# Altium schematic sheet con_brushless_v2.SchDoc
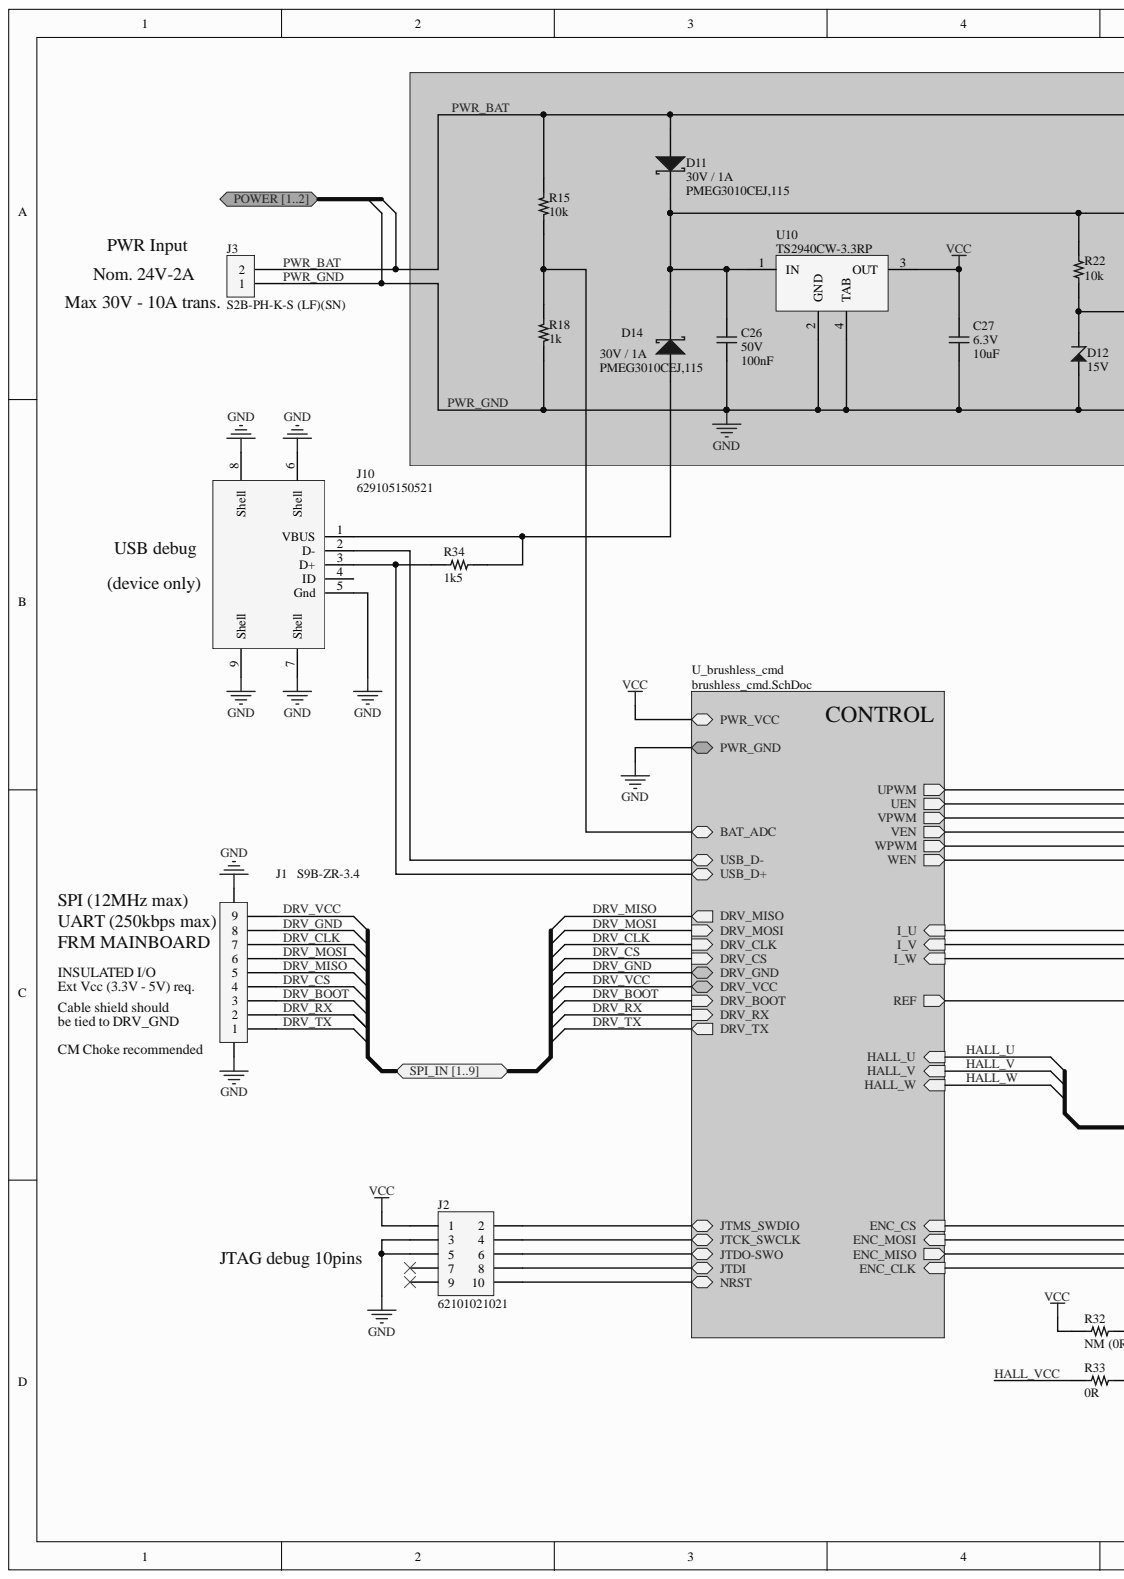
[diagram p0: con_brushless_v2.SchDoc, left]
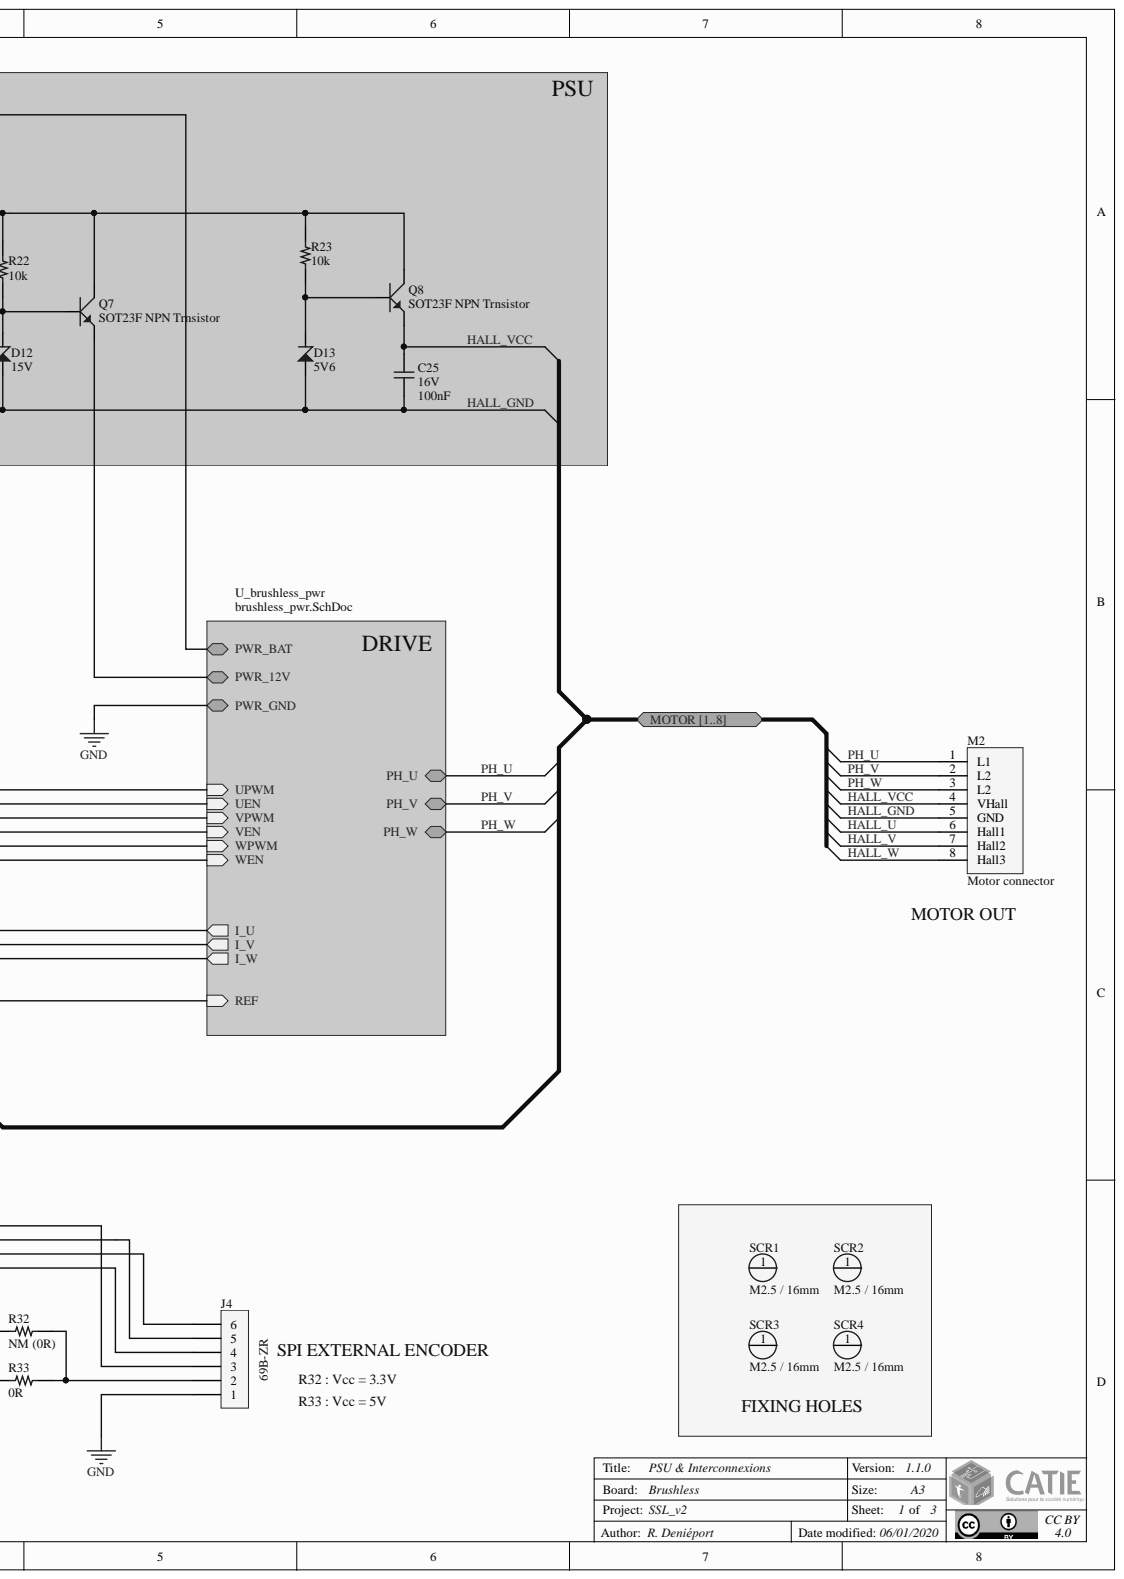
[diagram p1: con_brushless_v2.SchDoc, right]

## Parts
C25  value 100nF  Rating: 16V  [CAP_CER_0603]  (hidden comment: CAP_CER_0603)
C26  value 100nF  Rating: 50V  [CAP_CER_0603]  (hidden comment: CAP_CER_0603)
C27  value 10uF  Rating: 6.3V  [CAP_CER_0603]  (hidden comment: CAP_CER_0603)
D11  Rating: 30V / 1A  Reference: PMEG3010CEJ,115  [DIODE_SOD323F_SC90]  (hidden comment: Schottky Diode)
D12  value 15V  [DIODE_ZENER_BZD27C-SERIES_DO219-AB]  (hidden comment: DIODE_ZENER_BZD27C-SERIES_DO219-AB)
D13  value 5V6  [DIODE_ZENER_BZD27C-SERIES_DO219-AB]  (hidden comment: DIODE_ZENER_BZD27C-SERIES_DO219-AB)
D14  Rating: 30V / 1A  Reference: PMEG3010CEJ,115  [DIODE_SOD323F_SC90]  (hidden comment: Schottky Diode)
J1  Reference: S9B-ZR-3.4  [HEADER_JST_S9B-ZR-SM4A-TF_PITCH-1.5MM_9-PIN]  (hidden comment: HEADER_JST_S9B-ZR-SM4A-TF)
J2  Reference: 62101021021  [HEADER_WURTH_62101021021_10PIN]  (hidden comment: HEADER_WURTH_62101021021)
J3  Reference: S2B-PH-K-S (LF)(SN)  [HEADER_JST_S2B-PH-K-S_2MM_2-PIN_90°]  (hidden comment: HEADER_JST_S2B-PH-K-S_2MM_2-PIN_90°)
J4  Reference: 69B-ZR  [S6B-ZR]  (hidden comment: HEADER_JST_S6B-ZR-SM4A-TF_PITCH-1.5MM_6-PIN)
J10  Reference: 629105150521  [HEADER_MICROUSB_629105150521]  (hidden comment: HEADER_MICROUSB_629105150521)
M2  Motor connector  [66100811622]
Q7  SOT23F NPN Trnsistor  [TR_SOT23]
Q8  SOT23F NPN Trnsistor  [TR_SOT23]
R15  value 10k  [RES_0603]  (hidden comment: RES_0603)
R18  value 1k  [RES_0603]  (hidden comment: RES_0603)
R22  value 10k  [RES_0603]  (hidden comment: RES_0603)
R23  value 10k  [RES_0603]  (hidden comment: RES_0603)
R32  value NM (0R)  Mount: NM  [RES_0603]  (hidden comment: RES_0603)
R33  value 0R  [RES_0603]  (hidden comment: RES_0603)
R34  value 1k5  [RES_0603]  (hidden comment: RES_0603)
SCR1  value M2.5 / 16mm  [SPACER_M2.5_SW5.5_L16_MF_METAL_INV_TOP]  (hidden comment: Spacer M3 SW5.5 L16 MF Metal Inv)
SCR2  value M2.5 / 16mm  [SPACER_M2.5_SW5.5_L16_MF_METAL_INV_TOP]  (hidden comment: Spacer M3 SW5.5 L16 MF Metal Inv)
SCR3  value M2.5 / 16mm  [SPACER_M2.5_SW5.5_L16_MF_METAL_INV_TOP]  (hidden comment: Spacer M3 SW5.5 L16 MF Metal Inv)
SCR4  value M2.5 / 16mm  [SPACER_M2.5_SW5.5_L16_MF_METAL_INV_TOP]  (hidden comment: Spacer M3 SW5.5 L16 MF Metal Inv)
U10  Reference: TS2940CW-3.3RP  [SOT-223]  (hidden comment: VOLTAGE REGULATOR)

## Ports
MOTOR 1, MOTOR 2, MOTOR 3, MOTOR 4, MOTOR 5, MOTOR 6, MOTOR 7, MOTOR 8, MOTOR [1..8], POWER 1, POWER 2, POWER [1..2], SPI_IN 1, SPI_IN 2, SPI_IN 3, SPI_IN 4, SPI_IN 5, SPI_IN 6, SPI_IN 7, SPI_IN 8, SPI_IN 9, SPI_IN [1..9]

## Nets
VCC: C27.2 J2.1 R32.2 U10.3(OUT)
DRV_BOOT: J1.3
DRV_CLK: J1.7
DRV_CS: J1.4
DRV_GND: J1.8
DRV_MISO: J1.5
DRV_MOSI: J1.6
DRV_RX: J1.2
DRV_TX: J1.1
DRV_VCC: J1.9
HALL_GND: C25.1 C26.1 C27.1 D12.2 D13.2 J1.10 J1.11 J2.3 J2.5 J3.1 J4.1 J10.5(Gnd) J10.6(Shell) J10.7(Shell) J10.8(Shell) J10.9(Shell) M2.5(GND) R18.2 U10.2(GND) U10.4(TAB)
HALL_U: M2.6(Hall1)
HALL_V: M2.7(Hall2)
HALL_VCC: C25.2 M2.4(VHall) Q8.2 R33.2
HALL_W: M2.8(Hall3)
PH_U: M2.1(L1)
PH_V: M2.2(L2)
PH_W: M2.3(L2)
PWR_BAT: D11.2 J3.2 R15.1
NetC26_2: C26.2 D11.1 D14.1 Q7.3 Q8.3 R22.2 R23.2 U10.1(IN)
NetD12_1: D12.1 Q7.1 R22.1
NetD13_1: D13.1 Q8.1 R23.1
NetD14_2: D14.2 J10.1(VBUS) R34.1
NetJ2_2: J2.2
NetJ2_4: J2.4
NetJ2_6: J2.6
NetJ2_7: J2.7
NetJ2_8: J2.8
NetJ2_9: J2.9
NetJ2_10: J2.10
NetJ4_2: J4.2 R32.1 R33.1
NetJ4_3: J4.3
NetJ4_4: J4.4
NetJ4_5: J4.5
NetJ4_6: J4.6
NetJ10_2: J10.2(D-)
NetJ10_3: J10.3(D+) R34.2
NetJ10_4: J10.4(ID)
NetQ7_2: Q7.2
NetR15_2: R15.2 R18.1
NetSCR1_1: SCR1.1
NetSCR2_1: SCR2.1
NetSCR3_1: SCR3.1
NetSCR4_1: SCR4.1
I_U:
I_V:
I_W:
N00170[1..8]:
N00179[1..2]:
N00182[1..9]:
REF:
UEN:
UPWM:
VEN:
VPWM:
WEN:
WPWM:

## Library data of the parts
C25 C26 = CAP_CER_0603: Capacitor ceramics SMD 0603; Ceram: X7R; Tol: 10%; Case: 0603; Component Kind: Standard; Library Name: Schematic_Components.SchLib; Mount: Mnt; Technology: CMS; Type: Capacitor
C27 = CAP_CER_0603: Capacitor ceramics SMD 0603; Ceram: X5R; Tol: 10%; Case: 0603; Component Kind: Standard; Library Name: Schematic_Components.SchLib; Mount: Mnt; Technology: CMS; Type: Capacitor
D11 D14 = DIODE_SCHOTTKY_SOD323F: Sckottky diode SOD323F-SC90; Manufacturer: NEXPERIA; Value: 520mV; Case: SOD323F; Component Kind: Standard; Library Name: Schematic_Components.SchLib; Mount: Mnt; Supplier 1: Digi-Key; Supplier Part Number 1: 1727-3868-1-ND; Technology: CMS; Type: Diode
D12 = DIODE_ZENER_BZD27C-SERIES_DO219-AB: Diode Zener BZD27C-series DO219-AB case; Manufacturer: Vishay; Rating: 800mW; Reference: BZD27C15P-E3-08; Tol: 1%; Case: SOD132F; Component Kind: Standard; Library Name: Schlib1.SchLib; Mount: Mnt; Supplier 1: Digi-Key; Supplier Part Number 1: BZD27C15P-E3-08CT-ND; Technology: CMS; Type: Diode
D13 = DIODE_ZENER_BZD27C-SERIES_DO219-AB: Diode Zener BZD27C-series DO219-AB case; Manufacturer: Vishay; Rating: 800mW; Reference: BZD27C5V6P-E3-08; Tol: 1%; Case: SOD132F; Component Kind: Standard; Library Name: Schlib1.SchLib; Mount: Mnt; Supplier 1: Digi-Key; Supplier Part Number 1: BZD27C15P-E3-08CT-ND; Technology: CMS; Type: Diode
J1 = HEADER_JST_S9B-ZR-SM4A-TF: HEADER_JST_S9B-ZR-SM4A-TF_PITCH-1.5MM_9-PIN; Manufacturer: JST; Contacts: SZH-002T-P0.5; Female housing: ZHR-6; Pre-crimped cable 150mm: 01SZHSZH-30L-150; Pre-crimped cable 300mm: 01SZHSZH-30L-300; Rating: 1A / 50V; Value: 20mR; Component Kind: Standard; Library Name: Schlib1.SchLib; Mount: Mnt; Supplier 1: Digi-Key; Supplier Part Number 1: 455-1610-1-ND; Technology: CMS; Type: Header
J2 = HEADER_WURTH_62101021021: Header WR-PHD 1.27mm pitch SMT Straight Dual-10Pin; Manufacturer: Wurth; Mount: NM; Rating: 1A, 100V(AC); Value: 20mR; Component Kind: Standard; Library Name: Schlib1.SchLib; Technology: CMS; Type: Header
J3 = HEADER_JST_S2B-PH-K-S_2MM_2-PIN_90°: Header 2-pin 2mm pitch; Manufacturer: JST; Comment_1: Header JST S2B-PH-K-S; Pre-criped cable 150mm: 01SPHSPH-26001L150; Pre-criped cable 300mm: 01SPHSPH-26001L300; Rating: 2A/100V; Component Kind: Standard; Library Name: Schematic_Components.SchLib; Mount: Mnt; Pitch: 2mm; Supplier 1: Digi-Key; Supplier Part Number 1: 455-1719-ND; Technology: TRAD; Type: Header
J4 = HEADER_JST_S6B-ZR: Manufacturer: JST; Contacts: SZH-002T-P0.5; Female housing: ZHR-6; Pre-crimped cable 150mm: 01SZHSZH-30L-150; Pre-crimped cable 300mm: 01SZHSZH-30L-300; Rating: 1A / 50V; Value: 20mR; Component Kind: Standard; Description: HEADER_JST_S6B-ZR-SM4A-TF_PITCH-1.5MM_6-PIN; Library Name: Schlib1.SchLib; Mount: Mnt; Supplier 1: Digi-Key; Supplier Part Number 1: 455-1610-1-ND; Technology: CMS; Type: Header
J10 = HEADER_MICROUSB_629105150521: Header microUSB Type-B SMD; Manufacturer: Wurth Elektronik; Rating: 3A; Value: USB - Type B; Component Kind: Standard; Library Name: Schematic_Components.SchLib; Mount: Mnt; Supplier 1: Digi-Key; Supplier Part Number 1: 732-5960-1-ND; Technology: CMS; Type: Header
M2 = MOTOR_CON_EC397172_V2: Header 8-pin 1row; Manufacturer: Wurth Elektronik; Female housing: 661008151922; Component Kind: Standard; Library Name: Schlib1.SchLib; Mount: Mnt; Reference: 66100811622; Technology: TRAD; Type: Header
Q7 Q8 = Q_NPN: General purpose bipolar NPN transistor; Manufacturer: Diode Inc; Reference: ZXTN19020CFF; Case: SOT-23; Component Kind: Standard; Library Name: Schlib1.SchLib; Mount: Mnt; Technology: CMS; Type: Transistor
R15 R18 R22 R23 R32 R33 = RES_0603: Resistor SMD 0603; Case: 0603; Component Kind: Standard; Library Name: Schematic_Components.SchLib; Technology: CMS; Type: Resistor
  R15 R18 R22 R23 R33: Mount: Mnt
R34 = RES_0603: Resistor SMD 0603; Mount: NM; Case: 0603; Component Kind: Standard; Library Name: Schematic_Components.SchLib; Technology: CMS; Type: Resistor
SCR1-SCR4 = SPACER_M2.5_SW5.5_L16_MF_METAL_INV_TOP: Spacer M3 16mm long male-female metal inverted Top; Manufacturer: Wurth Elektronik; Mount: NM; Reference: 971160321; Component Kind: Standard; Library Name: Schlib1.SchLib; Technology: TRAD; Type: Meca
  SCR2: Supplier 1: Digi-Key; Supplier Part Number 1: 732-10423-ND
U10 = IC_TS2940: SOT-223 VOLTAGE REGULATOR; Manufacturer: TSC; Value: 3.3V; Case: SOT-223; Component Kind: Standard; Library Name: Schlib1.SchLib; Technology: CMS
